# Altium schematic sheet logi-cam-mt9v.SchDoc(logi-cam-mt9v)
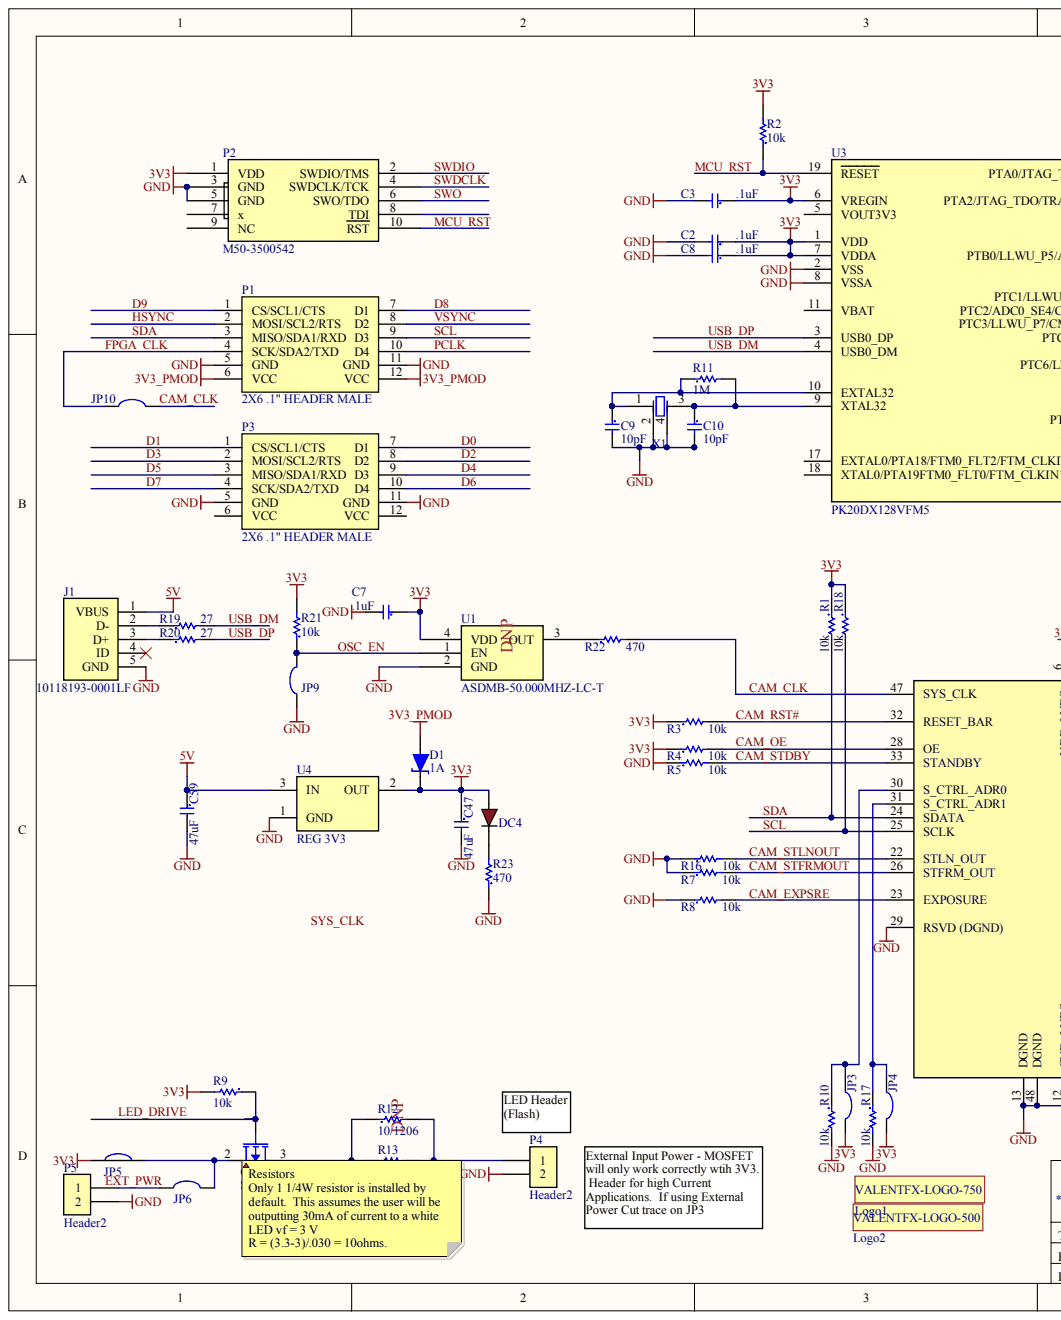
[diagram p0: logi-cam-mt9v.SchDoc, left]
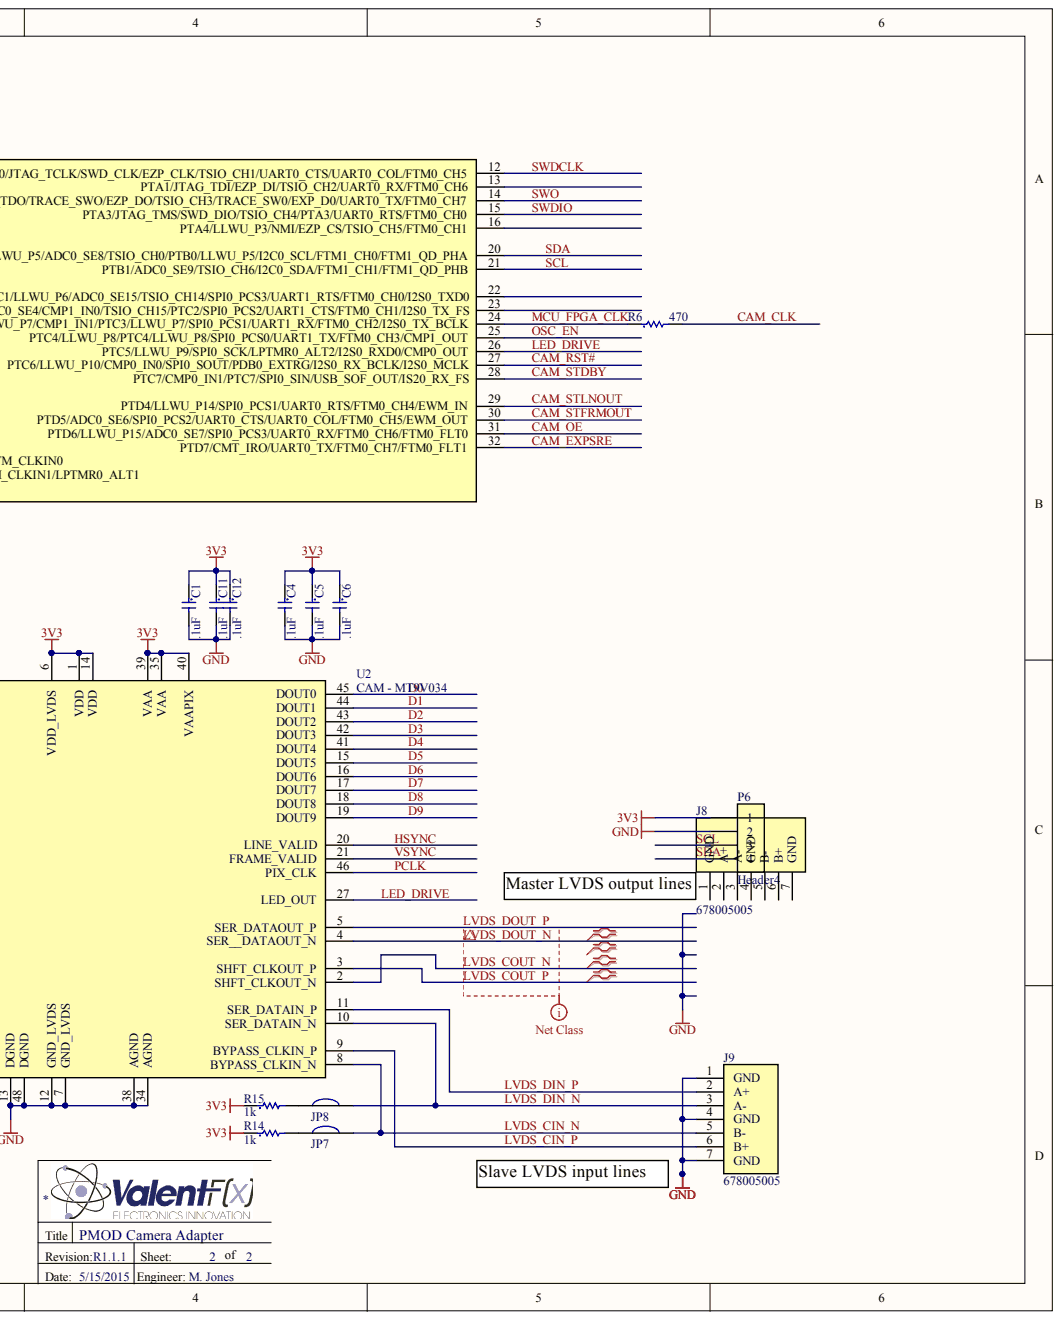
[diagram p1: logi-cam-mt9v.SchDoc, right]

## Parts
C1  .1uF  [C0603]
C2  .1uF  [C0603]
C3  .1uF  [C0603]
C4  .1uF  [C0603]
C5  .1uF  [C0603]
C6  .1uF  [C0603]
C7  .1uF  [C0603]
C8  .1uF  [C0603]
C9  10pF  [C0603]
C10  10pF  [C0603]
C11  .1uF  [C0603]
C12  .1uF  [C0603]
C47  47uF  [C0805IPC-CAPC2012]
C59  47uF  [C0805IPC-CAPC2012]
D1  1A  [SD0805S020S1R0]
DC4  598-8010-107F  [LED_0603-RED]  (value not drawn)
J1  10118193-0001LF  [USB - 10118193-0001LF_LOW-COST-FAB]
J8  678005005  [SATA-67800-5005]
J9  678005005  [SATA-67800-5005]
JP3  Solder Jumper  [SOLDER_JUMPER]  (value not drawn)  (no BOM)
JP4  Solder Jumper  [SOLDER_JUMPER]  (value not drawn)  (no BOM)
JP5  Solder Jumper-NC  [SOLDER_JUMPER-NC]  (value not drawn)
JP6  Solder Jumper  [SOLDER_JUMPER]  (value not drawn)  (no BOM)
JP7  Solder Jumper-NC  [SOLDER_JUMPER-NC]  (value not drawn)
JP8  Solder Jumper-NC  [SOLDER_JUMPER-NC]  (value not drawn)
JP9  Solder Jumper-NO  [SOLDER_JUMPER]  (value not drawn)
JP10  Solder Jumper-NO  [SOLDER_JUMPER]  (value not drawn)
Logo1  Valent Logo 750  [ValentFX_750]  (value not drawn)  (no BOM)
Logo2  Valent Logo 500  [VALENTFX_500-SolderMask]  (value not drawn)  (no BOM)
P1  2X6 .1" HEADER MALE  [PMOD HEADER - Male Vertical]
P2  M50-3500542  [M50-3500542]
P3  2X6 .1" HEADER MALE  [PMOD HEADER - Male Vertical]
P4  Header2  [HEADER_2_40_100 THRU SIP]  (no BOM)
P5  Header2  [HEADER_2_40_100 THRU SIP]  (no BOM)
P6  Header4  [HEADER_4_40_100 THRU SIP - duplicate]
Q1  Si2347DS-T1-GE3  [sot-23]
R1  10k  [R0603]
R2  10k  [R0603]
R3  10k  [R0603]
R4  10k  [R0603]
R5  10k  [R0603]
R6  470  [R0603]
R7  10k  [R0603]
R8  10k  [R0603]
R9  10k  [R0603]
R10  10k  [R0603]
R11  1M  [R0603]
R12  10/1206  [R1206]
R13  10/1206  [R1206]
R14  1k  [R0603]
R15  1k  [R0603]
R16  10k  [R0603]
R17  10k  [R0603]
R18  10k  [R0603]
R19  27  [R0603]
R20  27  [R0603]
R21  10k  [R0603]
R22  470  [R0603]
R23  470  [R0603]
U1  ASDMB-50.000MHZ-LC-T  [ASDMB - osc]
U2  CAM - MT9V034  [CAM - MT9V034 - easier]
U3  PK20DX128VFM5  [MCU - PK20DX128VFM5 - QFN50P500X500X100-32L]
U4  REG 3V3  [SOT-23]
X1  Load Capacitance: 10pF  [ABM10-16MHZ-E20-T]  (hidden comment: ABM10-16.000MHZ-E20-T)

## Nets
3V3: C1.1 C2.1 C3.1 C4.1 C5.1 C6.1 C7.1 C8.1 C11.1 C12.1 C47.1 D1.C DC4.A JP3.2 JP4.2 JP5.1 P2.1(VDD) P6.1 R1.1 R2.2 R3.1 R4.1 R9.2 R14.1 R15.1 R18.1 R21.2 U1.4(VDD) U2.1(VDD) U2.6(VDD_LVDS) U2.14(VDD) U2.35(VAA) U2.39(VAA) U2.40(VAAPIX) U3.1(VDD) U3.6(VREGIN) U3.7(VDDA) U4.2
3V3_PMOD: D1.A P1.6(VCC) P1.12(VCC)
5V: C59.1 J1.1(VBUS) U4.3
GND: C1.2 C2.2 C3.2 C4.2 C5.2 C6.2 C7.2 C8.2 C9.2 C10.2 C11.2 C12.2 C47.2 C59.2 J1.5(GND) J9.1(GND) J9.4(GND) J9.7(GND) JP9.1 P1.5(GND) P1.11(GND) P2.3(GND) P2.5(GND) P3.5(GND) P3.11(GND) P4.2 P5.2 P6.2 R5.1 R7.1 R8.1 R10.2 R16.1 R17.2 R23.1 U1.2(GND) U2.7(GND_LVDS) U2.12(GND_LVDS) U2.13(DGND) U2.29(RSVD_(DGND)) U2.34(AGND) U2.38(AGND) U2.48(DGND) U3.2(VSS) U3.8(VSSA) U4.1 X1.2 X1.4
CAM_CLK: JP10.2 R6.2 R22.2 U2.47(SYS_CLK)
CAM_EXPSRE: R8.2 U2.23(EXPOSURE) U3.32(PTD7/CMT_IRO/UART0_TX/FTM0_CH7/FTM0_FLT1)
CAM_OE: R4.2 U2.28(OE) U3.31(PTD6/LLWU_P15/ADC0_SE7/SPI0_PCS3/UART0_RX/FTM0_CH6/FTM0_FLT0)
CAM_RST#: R3.2 U2.32(RESET_BAR) U3.27(PTC6/LLWU_P10/CMP0_IN0/SPI0_SOUT/PDB0_EXTRG/I2S0_RX_BCLK/I2S0_MCLK)
CAM_STDBY: R5.2 U2.33(STANDBY) U3.28(PTC7/CMP0_IN1/PTC7/SPI0_SIN/USB_SOF_OUT/IS20_RX_FS)
CAM_STFRMOUT: R7.2 U2.26(STFRM_OUT) U3.30(PTD5/ADC0_SE6/SPI0_PCS2/UART0_CTS/UART0_COL/FTM0_CH5/EWM_OUT)
CAM_STLNOUT: R16.2 U2.22(STLN_OUT) U3.29(PTD4/LLWU_P14/SPI0_PCS1/UART0_RTS/FTM0_CH4/EWM_IN)
D0: P3.7(D1) U2.45(DOUT0)
D1: P3.1(CS/SCL1/CTS) U2.44(DOUT1)
D2: P3.8(D2) U2.43(DOUT2)
D3: P3.2(MOSI/SCL2/RTS) U2.42(DOUT3)
D4: P3.9(D3) U2.41(DOUT4)
D5: P3.3(MISO/SDA1/RXD) U2.15(DOUT5)
D6: P3.10(D4) U2.16(DOUT6)
D7: P3.4(SCK/SDA2/TXD) U2.17(DOUT7)
D8: P1.7(D1) U2.18(DOUT8)
D9: P1.1(CS/SCL1/CTS) U2.19(DOUT9)
FPGA_CLK: JP10.1 P1.4(SCK/SDA2/TXD)
HSYNC: P1.2(MOSI/SCL2/RTS) U2.20(LINE_VALID)
LED_DRIVE: Q1.1 R9.1 U2.27(LED_OUT) U3.26(PTC5/LLWU_P9/SPI0_SCK/LPTMR0_ALT2/I2S0_RXD0/CMP0_OUT)
LVDS_CIN_N: J9.5(B-) JP7.2 U2.8(BYPASS_CLKIN_N)
LVDS_CIN_P: J9.6(B+) U2.9(BYPASS_CLKIN_P)
LVDS_COUT_N: U2.2(SHFT_CLKOUT_N)
LVDS_COUT_P: U2.3(SHFT_CLKOUT_P)
LVDS_DIN_N: J9.3(A-) JP8.2 U2.10(SER_DATAIN_N)
LVDS_DIN_P: J9.2(A+) U2.11(SER_DATAIN_P)
LVDS_DOUT_N: U2.4(SER__DATAOUT_N)
LVDS_DOUT_P: U2.5(SER_DATAOUT_P)
MCU_FPGA_CLK: R6.1 U3.24(PTC3/LLWU_P7/CMP1_IN1/PTC3/LLWU_P7/SPI0_PCS1/UART1_RX/FTM0_CH2/I2S0_TX_BCLK)
MCU_RST: P2.10(RST) R2.1 U3.19(RESET)
OSC_EN: JP9.2 R21.1 U1.1(EN) U3.25(PTC4/LLWU_P8/PTC4/LLWU_P8/SPI0_PCS0/UART1_TX/FTM0_CH3/CMP1_OUT)
PCLK: P1.10(D4) U2.46(PIX_CLK)
SCL: P1.9(D3) P6.3 R18.2 U2.25(SCLK) U3.21(PTB1/ADC0_SE9/TSIO_CH6/I2C0_SDA/FTM1_CH1/FTM1_QD_PHB)
SDA: P1.3(MISO/SDA1/RXD) P6.4 R1.2 U2.24(SDATA) U3.20(PTB0/LLWU_P5/ADC0_SE8/TSIO_CH0/PTB0/LLWU_P5/I2C0_SCL/FTM1_CH0/FTM1_QD_PHA)
SWDCLK: P2.4(SWDCLK/TCK) U3.12(PTA0/JTAG_TCLK/SWD_CLK/EZP_CLK/TSIO_CH1/UART0_CTS/UART0_COL/FTM0_CH5)
SWDIO: P2.2(SWDIO/TMS) U3.15(PTA3/JTAG_TMS/SWD_DIO/TSIO_CH4/PTA3/UART0_RTS/FTM0_CH0)
SWO: P2.6(SWO/TDO) U3.14(PTA2/JTAG_TDO/TRACE_SWO/EZP_DO/TSIO_CH3/TRACE_SW0/EXP_D0/UART0_TX/FTM0_CH7)
USB_DM: R19.2 U3.4(USB0_DM)
USB_DP: R20.2 U3.3(USB0_DP)
VSYNC: P1.8(D2) U2.21(FRAME_VALID)
NetC9_1: C9.1 R11.1 U3.10(EXTAL32) X1.1
NetC10_1: C10.1 R11.2 U3.9(XTAL32) X1.3
NetDC4_C: DC4.C R23.2
NetJ1_2: J1.2(D-) R19.1
NetJ1_3: J1.3(D+) R20.1
NetJP3_1: JP3.1 R10.1 U2.30(S_CTRL_ADR0)
NetJP4_1: JP4.1 R17.1 U2.31(S_CTRL_ADR1)
NetJP5_2: JP5.2 JP6.2 Q1.2
NetJP6_1: JP6.1 P5.1
NetJP7_1: JP7.1 R14.2
NetJP8_1: JP8.1 R15.2
NetP4_1: P4.1 R12.2 R13.2
NetQ1_3: Q1.3 R12.1 R13.1
NetR22_1: R22.1 U1.3(OUT)
EXT_PWR:
N00036:
N00037:
SYS_CLK:

Not connected: J1 4(ID); J8 1(GND) 2(A+) 3(A-) 4(GND) 5(B-) 6(B+) 7(GND); P2 7(x) 8(TDI) 9(NC); P3 6(VCC) 12(VCC); U3 5(VOUT3V3) 11(VBAT) 13(PTA1/JTAG_TDI/EZP_DI/TSIO_CH2/UART0_RX/FTM0_CH6) 16(PTA4/LLWU_P3/NMI/EZP_CS/TSIO_CH5/FTM0_CH1) 17(EXTAL0/PTA18/FTM0_FLT2/FTM_CLKIN0) 18(XTAL0/PTA19FTM0_FLT0/FTM_CLKIN1/LPTMR0_ALT1) 22(PTC1/LLWU_P6/ADC0_SE15/TSIO_CH14/SPI0_PCS3/UART1_RTS/FTM0_CH0/I2S0_TXD0) 23(PTC2/ADC0_SE4/CMP1_IN0/TSIO_CH15/PTC2/SPI0_PCS2/UART1_CTS/FTM0_CH1/I2S0_TX_FS)

## Library data of the parts
C1-C8 C11 C12 = C0603 - C1608X7R1E104MT: .10uF X7R 20% (MLCC) - SMD/SMT 0603 25volts; Manufacturer: TDK; Capacitance: 0.1 uF; Category: Multilayer Ceramic Capacitors (MLCC) - SMD/SMT; Component Kind: Standard; ComponentLink1Description: http://www.tdk.co.jp/tefe02/e411_c.pdf; ComponentLink1URL: http://www.tdk.co.jp/tefe02/e411_c.pdf; Dimensions: 0.8 mm W x 1.6 mm L; Library Name: mCapacitors.SchLib; Manufacturer Part Number: C1608X7R1E104MT; Minimum Order Quantity: 1; Operating Temperature Range: - 55 C to + 125 C; Package / Case: 0603 (1608 metric); Packaging: Reel; Pricing 1: 1=0.365, 50=0.149, 100=0.085, 500=0.045, 1000=0.030, 4000=0.027, 8000=0.023, 12000=0.019, 20000=0.018 (AUD); Product: General Type MLCCs; Product Category: Low & Medium Capacitance SMD Ceramic Chip Capacitors; Reeling: True; RoHS: RoHS Compliant By Exemption; RoHS - Mouser: E; Standard Pack Qty: 4000.0; Stock 1: 69148; Supplier 1: Mouser; Supplier Part Number 1: 810-C1608X7R1E104M; Temperature Coefficient / Code: X7R; Termination Style: SMD/SMT; Tolerance: 20 %; Value: .1uF; Voltage Rating: 25 Volts
C9 C10 = C0603 - GRM1885C1H100FA01D: 10pF 50volts C0G 1% (MLCC) - SMD/SMT 0603; Accept Compatible Replacement Part: Yes; Manufacturer: Murata; Temperature Coefficient / Code: C0G (NP0); Capacitance: 10 pF; Category: Multilayer Ceramic Capacitors (MLCC) - SMD/SMT; Component Kind: Standard; ComponentLink1Description: http://www.murata.com/products/catalog/pdf/c02e.pdf; ComponentLink1URL: http://www.murata.com/products/catalog/pdf/c02e.pdf; Dimensions: 0.8 mm W x 1.6 mm L x 0.8 mm H; Library Name: mCapacitors.SchLib; Manufacturer Part Number: GRM1885C1H100FA01D; Minimum Order Quantity: 1; Operating Temperature Range: - 55 C to + 125 C; Package / Case: 0603 (1608 metric); Packaging: Reel; Pricing 1: 1=0.203, 50=0.108, 100=0.081, 500=0.046, 1000=0.027, 4000=0.023, 8000=0.022, 12000=0.020, 20000=0.019 (AUD); Product: General Type MLCCs; Product Category: Low & Medium Capacitance SMD Ceramic Chip Capacitors; Reeling: True; RoHS: RoHS Compliant By Exemption; RoHS - Mouser: E; Series: GRM; Standard Pack Qty: 4000; Stock 1: 50987; Supplier 1: Mouser; Supplier Part Number 1: 81-GRM39C100B50D; Termination Style: SMD/SMT; Tolerance: 1 %; Value: 10pF; Voltage Rating: 50 Volts
C47 C59 = C0805 - CL21A476MQCLRNC: CAP CER 47UF 6.3V 20% X5R 0805; Manufacturer: Samsung Electro-Mechanics America, Inc; Capacitance: 47µF; Applications: General Purpose; Category: Capacitors; Component Kind: Standard; ComponentLink1Description: http://www.samsungsem.com/servlet/FileDownload?type=spec&file=CL21A476MQCLRNC.pdf; ComponentLink1URL: http://www.samsungsem.com/servlet/FileDownload?type=spec&file=CL21A476MQCLRNC.pdf; Features: -; Height - Seated (Max): -; Lead Spacing: -; Lead Style: -; Library Name: mCapacitors.SchLib; Manufacturer Part Number: CL21A476MQCLRNC; Mounting Type: Surface Mount, MLCC; Operating Temperature: -55°C ~ 85°C; Package / Case: 0805 (2012 Metric); Packaging: Tape & Reel (TR); Pricing 1: 4000=0.165, 8000=0.154, 12000=0.15125, 28000=0.1485, 100000=0.1375 (USD); Ratings: -; RoHS: RoHS Compliant; Size / Dimension: 0.079" L x 0.049" W (2.00mm x 1.25mm); Stock 1: 332000; Supplier 1: Digi-Key; Supplier Part Number 1: 1276-2420-2-ND; Temperature Coefficient: X5R; Thickness (Max): 0.037" (0.95mm); Tolerance: ±20%; Voltage - Rated: 6.3V
D1 = SD0805S020S1R0: DIODE SCHOTTKY 20V 1A 0805; Manufacturer: AVX Corporation; Current - Average Rectified (Io): 1A (DC); Current - Reverse Leakage @ Vr: 200µA @ 20V; Speed: Fast Recovery =< 500ns, > 200mA (Io); Voltage - Forward (Vf) (Max) @ If: 450mV @ 1A; Capacitance @ Vr, F: -; Category: Discrete Semiconductor Products; Component Kind: Standard; ComponentLink1Description: http://www.avx.com/docs/Catalogs/schottky.pdf; ComponentLink1URL: http://www.avx.com/docs/Catalogs/schottky.pdf; Diode Type: Schottky; Library Name: mDiode.SchLib; Manufacturer Part Number: SD0805S020S1R0; Mounting Type: Surface Mount; Operating Temperature - Junction: -55°C ~ 125°C; Package / Case: 0805 (2012 Metric); Packaging: Cut Tape (CT); Pricing 1: 1=0.4, 10=0.315, 25=0.266, 100=0.2166, 250=0.1794, 500=0.1482, 1000=0.111 (USD); Reverse Recovery Time (trr): -; RoHS: RoHS Compliant; Stock 1: 25787; Supplier 1: Digi-Key; Supplier Device Package: 0805 (2012 Metric); Supplier Part Number 1: 478-7800-1-ND; Voltage - DC Reverse (Vr) (Max): 20V
DC4 = LED0603 - 598-8010-107F: LED ALINGAP RED CLEAR 0603 SMD; Manufacturer: Dialight; Accept Replacement: YES - Water Clear; Current - Test: 20mA; Lens Style/Size: Rectangle with Flat Top, 1.1mm x 0.8mm; Millicandela Rating: 40mcd; Viewing Angle: 140°; Voltage - Forward (Vf) Typ: 2.2V; Wavelength - Dominant: 635nm; Category: Optoelectronics; Color: Red; Component Kind: Standard; ComponentLink1Description: http://media.digikey.com/pdf/Data%20Sheets/Dialight%20PDFs/598_Series_0603_Pkg.pdf; ComponentLink1URL: http://media.digikey.com/pdf/Data%20Sheets/Dialight%20PDFs/598_Series_0603_Pkg.pdf; Height: 0.70mm; Lens Type: Clear; Library Name: mDiode.SchLib; Luminous Flux @ Current - Test: -; Manufacturer Part Number: 598-8010-107F; Mounting Type: Surface Mount; Package / Case: 0603 (1608 Metric); Packaging: Digi-Reel®; Pricing 1: 1=0.45, 10=0.33, 25=0.264, 100=0.1749, 250=0.1452, 500=0.1056, 1000=0.0825 (USD); RoHS: RoHS Compliant; Size / Dimension: 1.60mm L x 0.80mm W; Stock 1: 37578; Supplier 1: Digi-Key; Supplier Part Number 1: 350-2029-6-ND; Wavelength - Peak: -
J1 = USB - 10118193-0001LF: CONN USB MICRO B RECPT SMT R/A; Manufacturer: FCI; Connector Type: USB - micro B; Gender: Receptacle; Number of Contacts: 5; Specifications: USB 2.0; Category: Connectors, Interconnects; Component Kind: Standard; ComponentLink1Description: http://portal.fciconnect.com/Comergent//fci/drawing/10118193.pdf; ComponentLink1URL: http://portal.fciconnect.com/Comergent//fci/drawing/10118193.pdf; Features: Shielded, Solder Retention; Ingress Protection: -; Library Name: mConnector.SchLib; Manufacturer Part Number: 10118193-0001LF; Mounting Type: Surface Mount, Right Angle, Horizontal; Packaging: Cut Tape (CT); Pricing 1: 1=0.46, 10=0.433, 25=0.3712, 50=0.3156, 100=0.3032, 250=0.27224, 500=0.25988 (USD); RoHS: RoHS Compliant; Stock 1: 75921; Supplier 1: Digi-Key; Supplier Part Number 1: 609-4616-1-ND
J8 J9 = SATA - 67800-5005: I/O Connectors 7Ckt Vert Signal Hdr SMT w/ locking latch; Manufacturer: Molex; Current Rating: 1.5 A; Gender: Male; Mounting Angle: Vertical; Number of Positions / Contacts: 7; Pitch: 1.27 mm; Voltage Rating: 15 VDC; Category: I/O Connectors; Color: Black; Component Kind: Standard; ComponentLink1Description: http://www.mouser.com/ds/1/276/0678005005_PCB_HEADERS-119857.pdf; ComponentLink1URL: http://www.mouser.com/ds/1/276/0678005005_PCB_HEADERS-119857.pdf; Contact Material: Brass; Contact Plating: Gold; Flammability Rating: UL 94 V-0; Housing Material: Thermoplastic; Library Name: mConnector.SchLib; Manufacturer Part Number: 67800-5005; Minimum Order Quantity: 1; Mounting Style: Through Hole; Operating Temperature Range: - 35 C to + 85 C; Packaging: Tray; Pricing 1: 1=1.05, 10=0.929, 25=0.837, 50=0.801, 100=0.728, 250=0.655, 500=0.582, 1000=0.528, 1500=0.524 (AUD); Product: SATA Connectors; Product Category: SAS / SATA Connectors; Reeling: False; RoHS: RoHS Compliant; RoHS - Mouser: Y; Series: 67800; Standard Pack Qty: 4800; Stock 1: 2502; Supplier 1: Mouser; Supplier Part Number 1: 538-67800-5005; Termination Style: Solder Tail; Value: 678005005
JP3 JP4 JP6 = Solder Jumper: Solder/Configuration Jumper; Library Name: mConnector.SchLib; Manufacturer: n/a; Manufacturer Part Number: n/a; ROHS Certificate: n/a; Source: n/a; Source Part Number: n/a
JP5 JP7 JP8 = Solder Jumper-NC: Solder/Configuration Jumper; Component Kind: Net Tie; Library Name: mConnector.SchLib; Manufacturer: n/a; Manufacturer Part Number: n/a; ROHS Certificate: n/a; Source: n/a; Source Part Number: n/a
JP9 JP10 = Solder Jumper-NO: Solder/Configuration Jumper; Component Kind: Net Tie; Library Name: mConnector.SchLib; Manufacturer: n/a; Manufacturer Part Number: n/a; ROHS Certificate: n/a; Source: n/a; Source Part Number: n/a
P1 P3 = P-MOD - PRPC006DAAN-RC: CONN HEADER .100" DUAL STR 12 POS; Manufacturer: Sullins Connector Solutions; Connector Type: Header, Unshrouded; Number of Positions: 12; Number of Positions Loaded: All; Pitch: 0.100" (2.54mm); Category: Connectors, Interconnects; Color: Black; Component Kind: Standard; ComponentLink1Description: http://media.digikey.com/PDF/Data%20Sheets/Sullins%20PDFs/xRxCzzzDxxN-RC_11636-B.pdf; ComponentLink1URL: http://media.digikey.com/PDF/Data%20Sheets/Sullins%20PDFs/xRxCzzzDxxN-RC_11636-B.pdf; Contact Finish: Gold; Contact Finish Thickness: Flash; Contact Mating Length: 0.230" (5.84mm); Contact Type: Male Pin; Fastening Type: -; Features: -; Library Name: mConnector.SchLib; Manufacturer Part Number: PRPC006DAAN-RC; Mounting Type: Through Hole; Number of Rows: 2; Packaging: Bulk; Pricing 1: 1=0.46, 10=0.41, 100=0.3378, 500=0.26702, 1000=0.22923, 5000=0.20912, 10000=0.20108 (USD); RoHS: RoHS Compliant; Row Spacing: 0.100" (2.54mm); Stock 1: 0; Supplier 1: Digi-Key; Supplier Part Number 1: S2011EC-06-ND; Termination: Solder; Value: 2X6 .1" HEADER MALE
P2 = JTAG - SWD-M50-3500542: CONN HDR 1.27MM VERT AU 10POS; Manufacturer: Harwin Inc; Connector Type: Unshrouded; Molding Height Above Board: 0.039" (1.00mm); Number of Positions: 10; Number of Positions Loaded: All; Pitch: 0.050" (1.27mm); Category: Connectors, Interconnects; Color: Black; Component Kind: Standard; ComponentLink1Description: http://harwin.com/includes/pdfs/M50-350.pdf; ComponentLink1URL: http://harwin.com/includes/pdfs/M50-350.pdf; Contact Finish: Gold; Contact Finish Thickness: 5.9µin (0.15µm); Contact Mating Length: 0.118" (3.00mm); Features: -; Height Stacking (Mating): -; Library Name: mConnector.SchLib; Manufacturer Part Number: M50-3500542; Mounting Type: Through Hole; Number of Rows: 2; Packaging: Bulk; Pricing 1: 1=1.16, 10=1.017, 25=0.9576, 50=0.9178, 100=0.8778, 250=0.798, 500=0.7182, 1000=0.6384, 2500=0.57855 (USD); RoHS: RoHS Compliant; Row Spacing: 0.050" (1.27mm); Stock 1: 18864; Supplier 1: Digi-Key; Supplier Part Number 1: 952-1383-ND; Termination: Solder
P4 P5 = Header2: Header 2 pin; Library Name: mConnector.SchLib
P6 = Header4: Header 4 pin; Component Kind: Standard; Library Name: mConnector.SchLib
Q1 = PMOS - Si2347DS-T1-GE3: MOSFET -30V .042Ohm@10V 5A P-Ch G-III; Accept Compatible Replacement Part: Yes; Manufacturer: Vishay Semiconductors; Configuration: Single; Continuous Drain Current: - 3.9 A; Fall Time: 9 ns; Forward Transconductance - Min: 10 S; Gate-Source Breakdown Voltage: 20 V; Gate Charge Qg: 6.9 nC; Power Dissipation: 1.7 W; Product Status: New Product; Rds On: 68 mOhms; Rise Time: 6 ns; Transistor Polarity: P-Channel; Typical Turn-Off Delay Time: 19 ns; Category: MOSFET; Component Kind: Standard; ComponentLink1Description: http://www.mouser.com/ds/2/427/si2347ds-279626.pdf; ComponentLink1URL: http://www.mouser.com/ds/2/427/si2347ds-279626.pdf; Drain-Source Breakdown Voltage: - 30 V; Library Name: mTransistor.SchLib; Manufacturer Part Number: Si2347DS-T1-GE3; Maximum Operating Temperature: + 150 C; Minimum Operating Temperature: - 55 C; Minimum Order Quantity: 1; Mounting Style: SMD/SMT; Package / Case: SOT-23-3; Packaging: Reel; Pricing 1: 1=0.203, 10=0.189, 100=0.135, 250=0.122, 500=0.108, 3000=0.095 (AUD); Product Category: P-Channel MOSFETs; Reeling: True; RoHS: RoHS Compliant; RoHS - Mouser: Y; Standard Pack Qty: 3000; Stock 1: 8482; Supplier 1: Mouser; Supplier Part Number 1: 78-SI2347DS-T1-GE3
R1-R5 R7-R10 R16-R18 R21 = R0603 - CRCW060310K0FKEA: 10k Thick Film Resistors - SMD 1/10watt 10Kohms 1%; Manufacturer: Vishay Dale; Power (Watts): 0.1W, 1/10W; Temperature Coefficient: ±100ppm/°C; Category: Resistors; Component Kind: Standard; ComponentLink1Description: http://www.vishay.com/doc?20035; ComponentLink1URL: http://www.vishay.com/doc?20035; Composition: Thick Film; Features: -; Height: 0.020" (0.50mm); Library Name: mResistors.SchLib; Manufacturer Part Number: CRCW060310K0FKEA; Number of Terminations: 2; Package / Case: 0603 (1608 Metric); Packaging: Cut Tape (CT); Pricing 1: 10=0.081, 50=0.0436, 200=0.0251, 1000=0.01711 (USD); Resistance (Ohms): 10K; RoHS: RoHS Compliant; Size / Dimension: 0.061" L x 0.033" W (1.55mm x 0.85mm); Stock 1: 997856; Supplier 1: Digi-Key; Supplier Part Number 1: 541-10.0KHCT-ND; Tolerance: ±1%; Value: 10k
R6 R22 R23 = R0603 - CRCW0603470RJNEA: RES 470 OHM 1/10W 5% 0603 SMD; Manufacturer: Vishay Dale; Power (Watts): 0.1W, 1/10W; Temperature Coefficient: ±200ppm/°C; Category: Resistors; Component Kind: Standard; ComponentLink1Description: http://www.vishay.com/docs/20035/dcrcwe3.pdf; ComponentLink1URL: http://www.vishay.com/docs/20035/dcrcwe3.pdf; Composition: Thick Film; Features: Automotive AEC-Q200 Qualified; Height: 0.020" (0.50mm); Library Name: mResistors.SchLib; Manufacturer Part Number: CRCW0603470RJNEA; Number of Terminations: 2; Operating Temperature: -55°C ~ 155°C; Package / Case: 0603 (1608 Metric); Packaging: Digi-Reel®; Pricing 1: 10=0.08, 50=0.0432, 200=0.0248, 1000=0.01694 (USD); Resistance (Ohms): 470; RoHS: RoHS Compliant; Size / Dimension: 0.061" L x 0.033" W (1.55mm x 0.85mm); Stock 1: 83706; Supplier 1: Digi-Key; Supplier Device Package: 0603 (1608 Metric); Supplier Part Number 1: 541-470GDKR-ND; Tolerance: ±5%
R11 = R0603 - CRCW06031M00FKEB: 1Mohms 1% Thick Film Resistors - SMD 1/10watt; Accept Compatible Replacement Part: Yes; Manufacturer: Vishay/Dale; Power Rating: 0.1 Watt (1/10 Watt); Temperature Coefficient: 100 PPM / K; Voltage Rating: 75 Volts; Category: Thick Film Resistors - SMD; Component Kind: Standard; ComponentLink1Description: http://www.vishay.com/doc?20035; ComponentLink1URL: http://www.vishay.com/doc?20035; Dimensions: 0.85 mm W x 1.55 mm L x 0.45 mm H; Library Name: mResistors.SchLib; Manufacturer Part Number: CRCW06031M00FKEB; Minimum Order Quantity: 1; Operating Temperature Range: - 55 C to + 155 C; Package / Case: 0603 (1608 metric); Packaging: Reel; Pricing 1: 1=0.108, 100=0.034, 1000=0.020, 5000=0.011, 10000=0.007, 50000=0.005, 100000=0.004 (AUD); Product: Thick Film Resistors SMD; Product Category: 0603 Thick Film Chip Resistors; Reeling: True; Resistance: 1 MOhms; RoHS: RoHS Compliant By Exemption; RoHS - Mouser: E; Series: CRCW; Standard Pack Qty: 10000; Stock 1: 68202; Supplier 1: Mouser; Supplier Part Number 1: 71-CRCW06031M00FKEB; Termination Style: SMD/SMT; Tolerance: 1 %; Value: 1M
R12 R13 = R1206 - ERJ-8ENF3301V: RES 3.3K OHM 1/4W 1% 1206 SMD; Accept Compatible Replacement Part: Yes; Manufacturer: Panasonic Electronic Components; Power (Watts): 0.25W, 1/4W; Temperature Coefficient: ±100ppm/°C; Category: Resistors; Component Kind: Standard; ComponentLink1Description: http://industrial.panasonic.com/www-cgi/jvcr13pz.cgi?E+PZ+3+AOA0002+ERJ8ENF3301V+7+WW; ComponentLink1URL: http://industrial.panasonic.com/www-cgi/jvcr13pz.cgi?E+PZ+3+AOA0002+ERJ8ENF3301V+7+WW; Composition: Thick Film; Features: -; Height: 0.028" (0.70mm); Library Name: mResistors.SchLib; Manufacturer Part Number: ERJ-8ENF3301V; Number of Terminations: 2; Package / Case: 1206 (3216 Metric); Packaging: Digi-Reel®; Pricing 1: 1=0.1, 50=0.0368, 100=0.028, 250=0.0208, 500=0.01536, 1000=0.01024 (USD); Resistance (Ohms): 3.3k; RoHS: RoHS Compliant; Size / Dimension: 0.126" L x 0.063" W (3.20mm x 1.60mm); Stock 1: 147970; Supplier 1: Digi-Key; Supplier Device Package: 1206; Supplier Part Number 1: P3.30KFDKR-ND; Tolerance: ±1%; Value: 3.3k
R14 R15 = R0603 - ERJ-3EKF1001V: 1Kohms 1% Thick Film Resistors - SMD 0603  Tol; Accept Compatible Replacement Part: Yes; Manufacturer: Panasonic Electronic Components; Power Rating: 0.1 Watt (1/10 Watt); Product Status: New at Mouser; Temperature Coefficient: 100 PPM / C; Category: Thick Film Resistors - SMD; Component Kind: Standard; ComponentLink1Description: http://www.panasonic.com/industrial/components/pdf/AOA0000CE2.pdf; ComponentLink1URL: http://www.panasonic.com/industrial/components/pdf/AOA0000CE2.pdf; Dimensions: 0.8 mm W x 1.6 mm L x 0.45 mm H; Library Name: mResistors.SchLib; Manufacturer Part Number: ERJ-3EKF1001V; Minimum Order Quantity: 1; Operating Temperature Range: - 55 C to + 125 C; Package / Case: 0603 (1608 metric); Packaging: Reel; Pricing 1: 1=0.054, 25=0.020, 100=0.014, 250=0.009, 500=0.007, 1000=0.004, 2000=0.003 (AUD); Product: Thick Film Resistors SMD; Reeling: True; Resistance: 1 KOhms; RoHS: RoHS Compliant By Exemption; RoHS - Mouser: E; Series: ERJ; Standard Pack Qty: 5000; Stock 1: 226610; Supplier 1: Mouser; Supplier Part Number 1: 667-ERJ-3EKF1001V; Termination Style: SMD/SMT; Tolerance: 1 %; Value: 1k
R19 R20 = R0603 - CR0603-FX-27R0ELF: 27 ohm 1% Thick Film Resistors - SMD; Accept Compatible Replacement Part: Yes; Manufacturer: Bourns; Power Rating: 0.1 Watt (1/10 Watt); Resistance: 27 Ohms; Voltage Rating: 50 Volts; Category: Thick Film Resistors - SMD; Component Kind: Standard; ComponentLink1Description: http://www.bourns.com/data/global/pdfs/CHPREZTR.pdf; ComponentLink1URL: http://www.bourns.com/data/global/pdfs/CHPREZTR.pdf; Dimensions: 0.8 mm W x 1.6 mm L x 0.45 mm H; Library Name: mResistors.SchLib; Manufacturer Part Number: CR0603-FX-27R0ELF; Minimum Order Quantity: 1; Operating Temperature Range: - 55 C to + 155 C; Package / Case: 0603 (1608 metric); Packaging: Reel; Pricing 1: 1=0.068, 10=0.027, 100=0.019, 500=0.018, 1000=0.016, 2500=0.015, 5000=0.012, 10000=0.011, 25000=0.009 (AUD); Product: Thick Film Resistors SMD; Product Category: SMD Chip Resistors; Reeling: True; RoHS: RoHS Compliant By Exemption; RoHS - Mouser: E; Series: CR0603; Standard Pack Qty: 5000; Stock 1: 11483; Supplier 1: Mouser; Supplier Part Number 1: 652-CR0603FX-27R0ELF; Termination Style: SMD/SMT; Tolerance: 1 %; Value: 27
U1 = ASDMB-50.000MHZ-LC-T: OSC MEMS 50.000 MHZ SMD; Manufacturer: Abracon Corporation; Current - Supply (Disable) (Max): 15µA; Current - Supply (Max): 16mA; Frequency: 50MHz; Frequency Stability: ±50ppm; Function: Tri-State (Output Enable); Output: CMOS; Voltage - Supply: 1.8 V ~ 3.3 V; Category: Crystals and Oscillators; Component Kind: Standard; ComponentLink1Description: http://www.abracon.com/Oscillators/ASDMB.pdf; ComponentLink1URL: http://www.abracon.com/Oscillators/ASDMB.pdf; Height: 0.035" (0.90mm); Library Name: eIC.SchLib; Manufacturer Part Number: ASDMB-50.000MHZ-LC-T; Mounting Type: Surface Mount; Operating Temperature: -40°C ~ 85°C; Package / Case: 4-SMD, No Lead (DFN, LCC); Packaging: Cut Tape (CT); Pricing 1: 1=1.69, 10=1.463, 50=1.2826, 100=1.0125, 500=0.855 (USD); RoHS: RoHS Compliant; Size / Dimension: 0.098" L x 0.079" W (2.50mm x 2.00mm); Stock 1: 967; Supplier 1: Digi-Key; Supplier Part Number 1: 535-11738-1-ND; Type: MEMS (Silicon); Value: ASDMB-50.000MHZ-LC-T
U2 = CAM - MT9V034: SENSOR IMAGE VGA MONO 48-CLCC; Manufacturer: Aptina LLC; Manufacturer Part Number: MT9V034C12STM; Active Pixel Array: 752H x 480V; Frames per Second: 60; Pixel Size: 6µm x 6µm; Voltage - Supply: 3.3V; Category: Sensors, Transducers; Component Kind: Standard; ComponentLink1Description: http://media.digikey.com/pdf/Data%20Sheets/APTINA%20PDFs/MT9V034.pdf; ComponentLink1URL: http://media.digikey.com/pdf/Data%20Sheets/APTINA%20PDFs/MT9V034.pdf; Library Name: mCamera_Modules.SchLib; Package / Case: 48-CLCC; Packaging: Tray; Pricing 1: 152=14.4925 (USD); RoHS: RoHS Compliant; Stock 1: 0; Supplier 1: Digi-Key; Supplier Device Package: 48-CLCC; Supplier Part Number 1: 557-1454-ND; Type: CMOS Imaging
U3 = MCU - PK20DX128VFM5: FREESCALE SEMICONDUCTOR - PK20DX128VFM5 - MCU, CORTEX M4, KINETIS, 32QFN; Component Kind: Standard; Library Name: mIC.SchLib; Manufacturer: FREESCALE SEMICONDUCTOR; Manufacturer Part Number: PK20DX128VFM5; Pricing 1: 1=6.06, 100=4.95, 250=4.55, 500=4.21, 1000=3.93 (USD); RoHS: YES; Stock 1: 10; Supplier 1: Newark; Supplier Part Number 1: 83T3025; Value: PK20DX128VFM5
U4 = REG - MCP1700T-3302E/TT: IC REG LDO 3.3V 250MA SOT23-3; Manufacturer: Microchip Technology; Current - Output: 250mA (Min); Number of Regulators: 1; Regulator Topology: Positive Fixed; Voltage - Dropout (Typical): 0.178V @ 250mA; Voltage - Input: Up to 6V; Category: Integrated Circuits (ICs); Component Kind: Standard; ComponentLink1Description: http://ww1.microchip.com/downloads/en/DeviceDoc/21826b.pdf; ComponentLink1URL: http://ww1.microchip.com/downloads/en/DeviceDoc/21826b.pdf; Current - Limit (Min): -; Library Name: mIC.SchLib; Manufacturer Part Number: MCP1700T-3302E/TT; Mounting Type: Surface Mount; Operating Temperature: -40°C ~ 125°C; Package / Case: TO-236-3, SC-59, SOT-23-3; Packaging: Cut Tape (CT); Pricing 1: 1=0.45, 10=0.37, 25=0.31, 100=0.28 (USD); RoHS: Request Inventory Verification; Stock 1: 30153; Supplier 1: Digi-Key; Supplier Device Package: SOT-23-3; Supplier Part Number 1: MCP1700T3302ETTCT-ND; Value: REG 3V3; Voltage - Output: 3.3V
X1 = XT - ABM10-16.000MHZ-E20-T: Micro 16MHz 10pF -20C +70C 20ppm; Manufacturer: Abracon Corporation; Operating Mode: Fundamental; Category: Crystals and Oscillators; Component Kind: Standard; ComponentLink1Description: http://www.abracon.com/Resonators/ABM10.pdf; ComponentLink1URL: http://www.abracon.com/Resonators/ABM10.pdf; Frequency: 16MHz; Frequency Stability: ±20ppm; Frequency Tolerance: ±20ppm; Height: 0.020" (0.50mm); Library Name: mCrystal.SchLib; Manufacturer Part Number: ABM10-16.000MHZ-E20-T; Mounting Type: Surface Mount; Operating Temperature: -20°C ~ 70°C; Package / Case: 4-SMD, No Lead (DFN, LCC); Packaging: Cut Tape (CT); Pricing 1: 1=2.44, 10=2.113, 50=1.8526, 100=1.4625, 500=1.235 (USD); RoHS: RoHS Compliant; Size / Dimension: 0.098" L x 0.079" W (2.50mm x 2.00mm); Stock 1: 7642; Supplier 1: Digi-Key; Supplier Part Number 1: 535-9810-1-ND; Type: MHz Crystal; Value: ABM10-16.000MHZ-E20-T
Logo1 = Valent Logo 750: Library Name: mLogos.SchLib
Logo2 = Valent Logo 500: Library Name: mLogos.SchLib
